# Altium schematic sheet TopSheet.SchDoc(TopSheet)
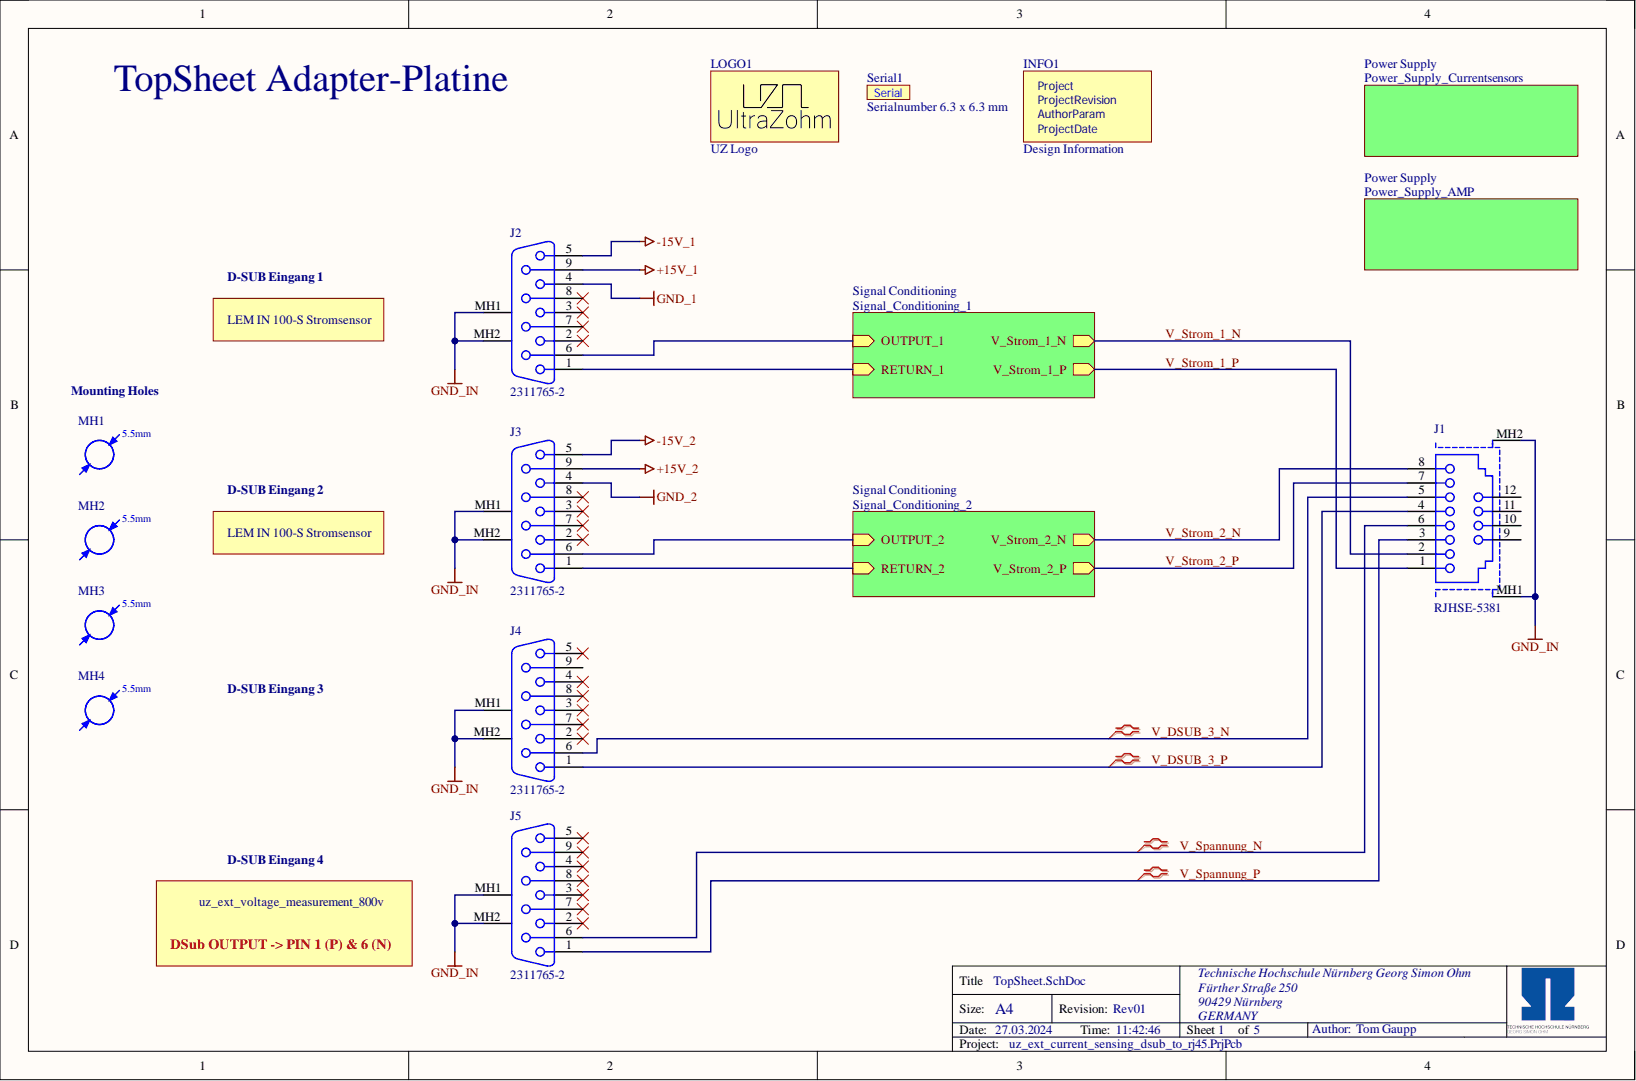
[diagram p0: TopSheet.SchDoc]

## Parts
INFO1  Design Information  [Design_info]  (no BOM)
J1  RJHSE-5381  [RJHSE-5381]
J2  2311765-2  [23117652]
J3  2311765-2  [23117652]
J4  2311765-2  [23117652]
J5  2311765-2  [23117652]
LOGO1  UZ Logo  value Logo  Component Type: Logo  [UZ_Logo_small]  (no BOM)
MH1  Mounting_Hole_M5  [Mounting Hole (M5)]  (value not drawn)
MH2  Mounting_Hole_M5  [Mounting Hole (M5)]  (value not drawn)
MH3  Mounting_Hole_M5  [Mounting Hole (M5)]  (value not drawn)
MH4  Mounting_Hole_M5  [Mounting Hole (M5)]  (value not drawn)
Serial1  Serialnumber 6.3 x 6.3 mm  [SERIAL_6.3X6.3MM]  (no BOM)

## Nets
+15V_1: J2.9
+15V_2: J3.9
-15V_1: J2.5
-15V_2: J3.5
GND_1: J2.4
GND_2: J3.4
GND_IN: J1.MH1 J1.MH2 J2.MH1 J2.MH2 J3.MH1 J3.MH2 J4.MH1 J4.MH2 J5.MH1 J5.MH2
V_DSUB_3_N: J1.5 J4.6
V_DSUB_3_P: J1.4 J4.1
V_Spannung_N: J1.6 J5.6
V_Spannung_P: J1.3 J5.1
V_Strom_1_N: J1.2
V_Strom_1_P: J1.1
V_Strom_2_N: J1.8
V_Strom_2_P: J1.7
NetJ1_9: J1.9
NetJ1_10: J1.10
NetJ1_11: J1.11
NetJ1_12: J1.12
NetJ2_1: J2.1
NetJ2_2: J2.2
NetJ2_3: J2.3
NetJ2_6: J2.6
NetJ2_7: J2.7
NetJ2_8: J2.8
NetJ3_1: J3.1
NetJ3_2: J3.2
NetJ3_3: J3.3
NetJ3_6: J3.6
NetJ3_7: J3.7
NetJ3_8: J3.8
NetJ4_2: J4.2
NetJ4_3: J4.3
NetJ4_4: J4.4
NetJ4_5: J4.5
NetJ4_7: J4.7
NetJ4_8: J4.8
NetJ4_9: J4.9
NetJ5_2: J5.2
NetJ5_3: J5.3
NetJ5_4: J5.4
NetJ5_5: J5.5
NetJ5_7: J5.7
NetJ5_8: J5.8
NetJ5_9: J5.9

## Library data of the parts
INFO1 = Design Information: Design InformationS; Manufacturer: UltraZohm; Created from: MGremer; Database Table Name: Misc; Component Type: Design Information; ComponentLink1Description: https://docs.ultrazohm.com/; ComponentLink1URL: homepage; Created On: 03.11.2021 09:04:23; Last Updated: 03.11.2021 09:28:34; Library Name: user.DbLib; Live Cycle Status: 1; Manufacturer Part Number: Design Information; PartID: 12; Supplier 1: -; Supplier Part Number 1: -; Type: Design Information; Value: Design Information
J1 = RJHSE-5381: Connector Ethernet R/A RJ45 SHIELDED 8 CONT Y/G LEDS; Component Kind: Standard; Library Name: eigene_lib_alt.SchLib
J2-J5 = 2311765-2: Connector D-Sub 9 Pin Female; Manufacturer_Name: TE Connectivity; Arrow Part Number: 2311765-2; Arrow Price/Stock: https://www.arrow.com/en/products/2311765-2/te-connectivity?region=nac; Component Kind: Standard; Datasheet Link: https://www.te.com/commerce/DocumentDelivery/DDEController?Action=showdoc&DocId=Customer+Drawing%7F2311765%7FD%7Fpdf%7FEnglish%7FENG_CD_2311765_D.pdf%7F2311765-2; Height: 12.8mm; Manufacturer_Part_Number: 2311765-2; Mouser Part Number: 571-2311765-2; Mouser Price/Stock: https://www.mouser.co.uk/ProductDetail/TE-Connectivity/2311765-2?qs=rrS6PyfT74frdzrH7SJRfg%3D%3D; Library Name: eigene_lib_alt.SchLib
LOGO1 = Logo small: Manufacturer: UltraZohm; Created from: MGremer; Database Table Name: Misc; ComponentLink1Description: https://docs.ultrazohm.com/; ComponentLink1URL: homepage; Created On: 03.11.2021 09:04:23; Description: UZ Logo; Last Updated: 03.11.2021 09:05:50; Library Name: user.DbLib; Live Cycle Status: 1; Manufacturer Part Number: Logo small; PartID: 10; Supplier 1: -; Supplier Part Number 1: -; Type: Logo; Created: Jan2021_Liegmann
MH1-MH4 = Mounting_Hole_M5: Mounting Hole; Component Kind: Standard; Library Name: Mounting_Hole_M5.Schlib
Serial1 = Serial Number: Manufacturer: UltraZohm; Created from: MGremer; Database Table Name: Misc; Value: REV; Component Type: Serial Number; ComponentLink1Description: https://docs.ultrazohm.com/; ComponentLink1URL: homepage; Created On: 03.11.2021 09:04:23; Description: Serial Number; Last Updated: 03.11.2021 09:28:34; Library Name: user.DbLib; Live Cycle Status: 1; Manufacturer Part Number: Serial Number; PartID: 11; Supplier 1: -; Supplier Part Number 1: -; Type: Serial Number; Created: 2018_09_18_AGeiger
